# Altium schematic sheet MiniF4xx_v2 - 副本.SchDoc
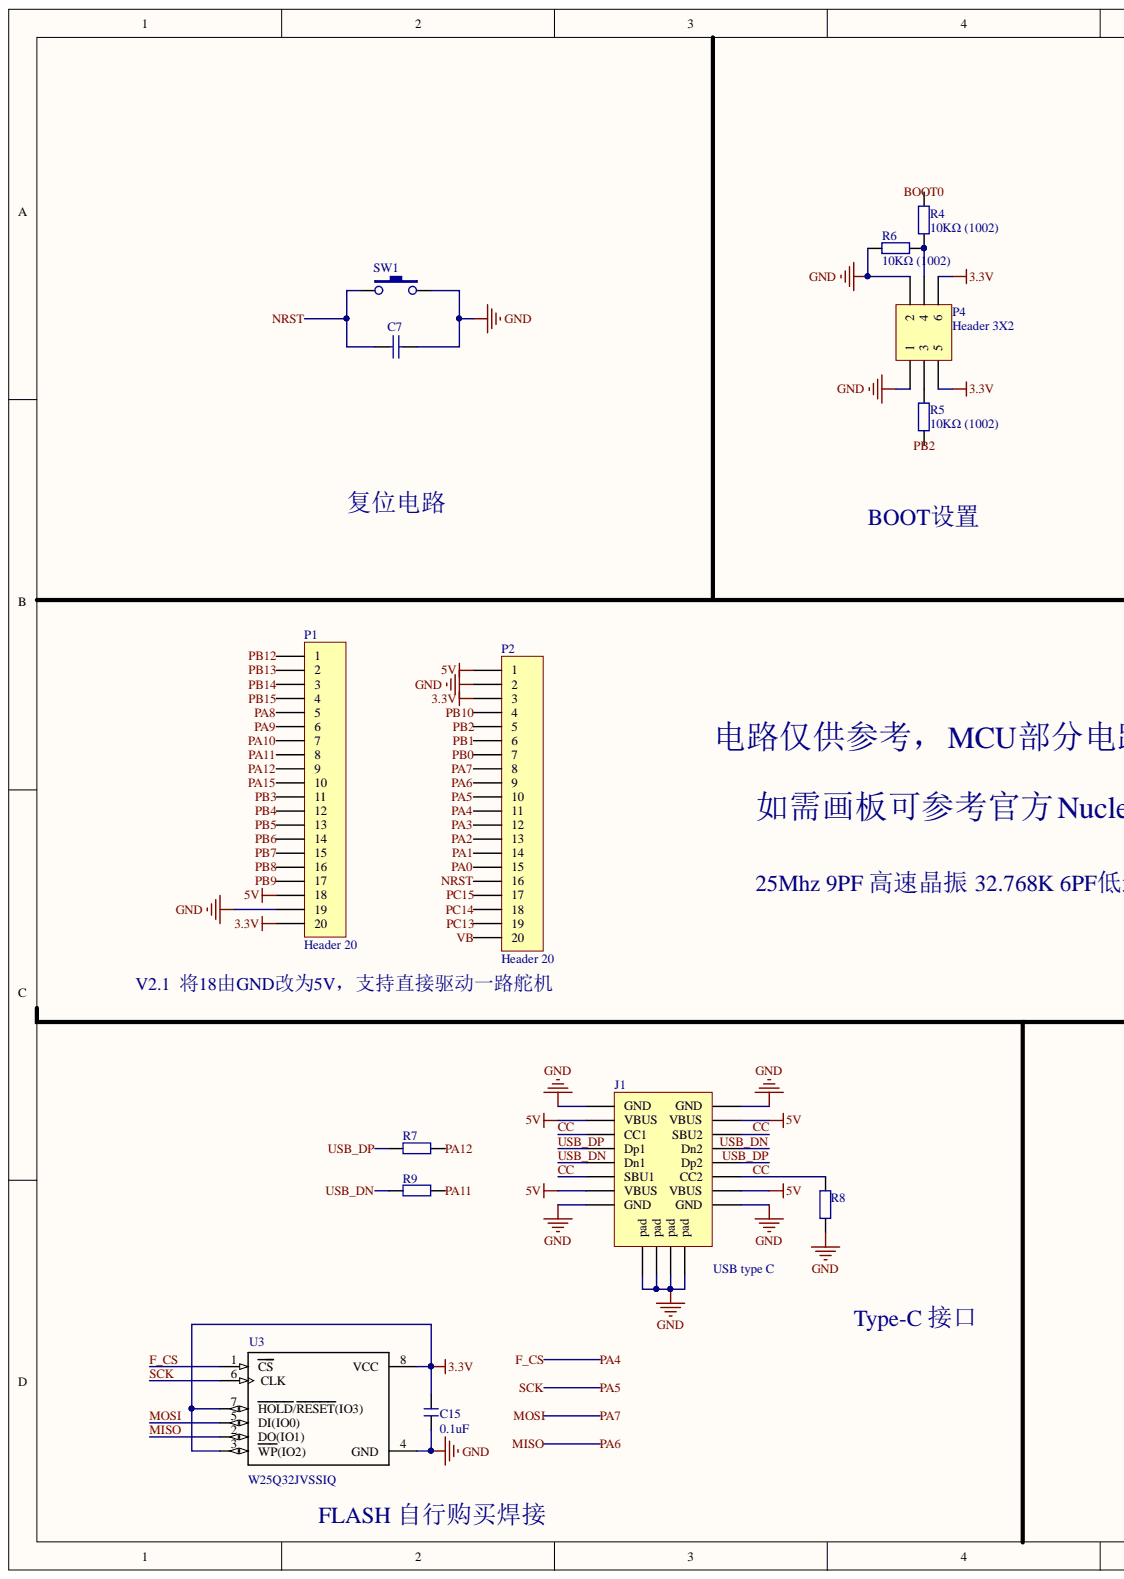
[diagram p0: MiniF4xx_v2 - 副本.SchDoc, left]
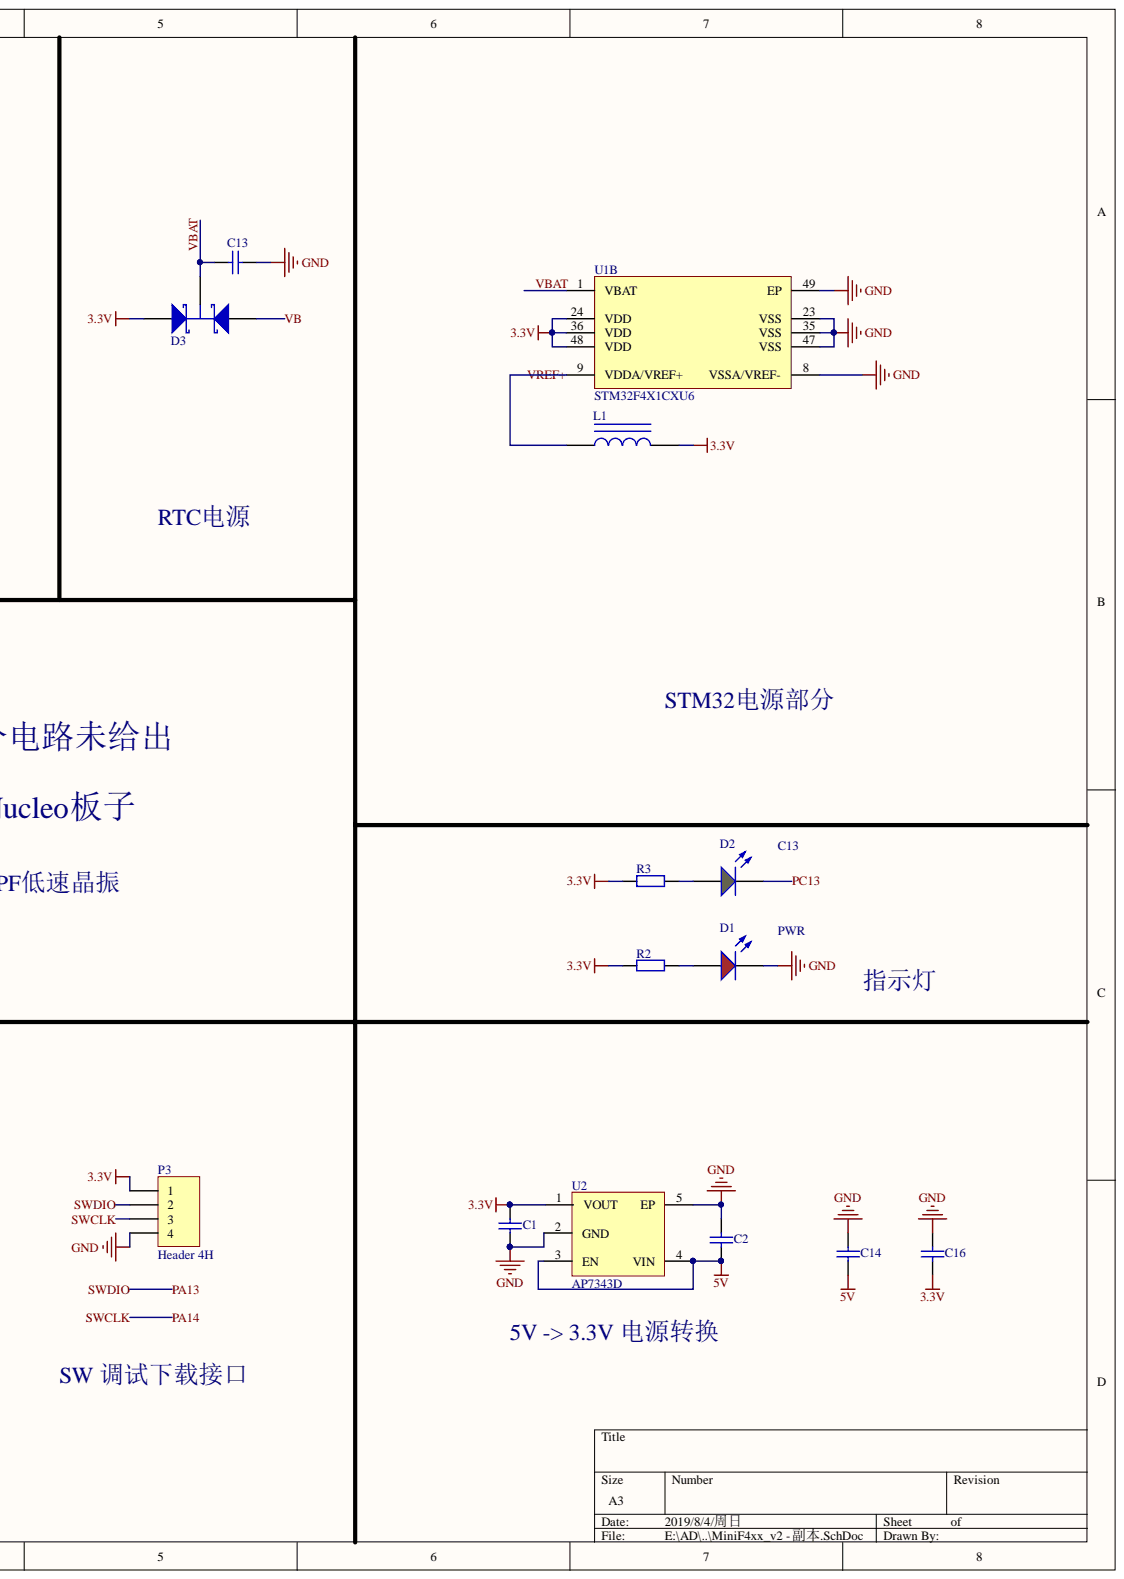
[diagram p1: MiniF4xx_v2 - 副本.SchDoc, right]

## Parts
C1  1.0uF  [0402_C]  (value not drawn)
C2  1.0uF  [0402_C]  (value not drawn)
C7  100nF  [0402_C]  (value not drawn)
C13  100nF  [0402_C]  (value not drawn)
C14  100nF  [0402_C]  (value not drawn)
C15  0.1uF  [C 0402]
C16  100nF  [0402_C]  (value not drawn)
D1  红灯 0603  [0603_LED_S1]  (value not drawn)
D2  蓝灯 0603  [0603_LED_S1]  (value not drawn)
D3  BAT54C  [SOT23_N]  (value not drawn)
J1  USB type C  [TYPE-C-USB-17]
L1  10uH ±10%  [0603_L]  (value not drawn)
P1  Header 20  [HDR1X20]
P2  Header 20  [HDR1X20]
P3  Header 4H  [HDR1X4H]
P4  Header 3X2  [SMD-2*3/1.27]
R2  1K  [0402_R]  (value not drawn)
R3  1K  [0402_R]  (value not drawn)
R4  10KΩ (1002)  [0402_R]
R5  10KΩ (1002)  [0402_R]
R6  10KΩ (1002)  [0402_R]
R7  10Ω  [0402_R]  (value not drawn)
R8  5.1KΩ  [0402_R]  (value not drawn)
R9  10Ω  [0402_R]  (value not drawn)
SW1  3*4 按键  [KEY-3.0*4.0_]  (value not drawn)
U1  STM32F4X1CXU6  [STM-UFQFPN48_N]  (part B)
U2  AP7343D  [X2-DFN1010-4]
U3  W25Q32JVSSIQ  [*SOIC127P790X216-8N]

## Nets
3.3V: C1.2 C15.1 C16.1 D3.1 L1.2 P1.20 P2.3 P3.1 P4.5 P4.6 R2.1 R3.1 U1.24(VDD) U1.36(VDD) U1.48(VDD) U2.1(VOUT) U3.3(WP(IO2)) U3.7(HOLD/RESET(IO3)) U3.8(VCC)
5V: C2.1 C14.1 J1.A4(VBUS) J1.A9(VBUS) J1.B4(VBUS) J1.B9(VBUS) P1.18 P2.1 U2.3(EN) U2.4(VIN)
GND: C1.1 C2.2 C7.2 C13.1 C14.2 C15.2 C16.2 D1.K J1.0(pad) J1.A1(GND) J1.A12(GND) J1.B1(GND) J1.B12(GND) P1.19 P2.2 P3.4 P4.1 P4.2 R6.2 R8.1 SW1.2 U1.8(VSSA/VREF-) U1.23(VSS) U1.35(VSS) U1.47(VSS) U1.49(EP) U2.2(GND) U2.5(EP) U3.4(GND)
BOOT0: R4.2
CC: J1.A5(CC1) J1.A8(SBU1) J1.B5(CC2) J1.B8(SBU2) R8.2
F_CS: P2.11 U3.1(CS)
MISO: P2.9 U3.2(DO(IO1))
MOSI: P2.8 U3.5(DI(IO0))
NRST: C7.1 P2.16 SW1.1
PA0: P2.15
PA1: P2.14
PA2: P2.13
PA3: P2.12
PA5: P2.10 U3.6(CLK)
PA8: P1.5
PA9: P1.6
PA10: P1.7
PA11: P1.8 R9.1
PA12: P1.9 R7.1
PA13: P3.2
PA14: P3.3
PA15: P1.10
PB0: P2.7
PB1: P2.6
PB2: P2.5 R5.1
PB3: P1.11
PB4: P1.12
PB5: P1.13
PB6: P1.14
PB7: P1.15
PB8: P1.16
PB9: P1.17
PB10: P2.4
PB12: P1.1
PB13: P1.2
PB14: P1.3
PB15: P1.4
PC13: D2.K P2.19
PC14: P2.18
PC15: P2.17
USB_DN: J1.A7(Dn1) J1.B7(Dn2) R9.2
USB_DP: J1.A6(Dp1) J1.B6(Dp2) R7.2
VB: D3.2 P2.20
VBAT: C13.2 D3.3 U1.1(VBAT)
VREF+: L1.1 U1.9(VDDA/VREF+)
NetD1_A: D1.A R2.2
NetD2_A: D2.A R3.2
NetP4_3: P4.3 R5.2
NetP4_4: P4.4 R4.1 R6.1

## Library data of the parts
C1 C2 = 0402 1.0uF (105) 10% 25V: 贴片电容; Component Kind: Standard; ComponentLink1Description: 供应商链接; ComponentLink1URL: http://www.szlcsc.com/product/details_53938.html; Library Name: Cap.SchLib; Package: 0402; Supplier: LC; SuppliersPartNumber: C52923
C7 C13 C14 C16 = 0402 100nF (104) 10% 16V: 贴片电容; Component Kind: Standard; ComponentLink1Description: 供应商链接; ComponentLink1URL: http://www.szlcsc.com/product/details_1877.html; Library Name: Cap.SchLib; Package: 0402; Supplier: LC; SuppliersPartNumber: C1525
C15 = C: 无极性贴片电容; 版权归属: 源创客; BOM名称: 无极性贴片电容; Component Kind: Standard; Library Name: PMW3901 V1.1.SCHLIB; 学习资源: www.ooocke.com
D1 = 0603 红灯: 发光二极管; Component Kind: Standard; ComponentLink1Description: 供应商链接; ComponentLink1URL: http://www.szlcsc.com/product/details_2659.html; Library Name: LED.SchLib; Package: 0603; Supplier: LC; SuppliersPartNumber: C2286
D2 = 0603 绿灯: 发光二极管; Component Kind: Standard; ComponentLink1Description: 供应商链接; ComponentLink1URL: http://www.szlcsc.com/product/details_73152.html; Library Name: LED.SchLib; Package: 0603; Supplier: LC; SuppliersPartNumber: C72043
D3 = BAT54C: Schottky Barrier Double Diode; Component Kind: Standard; ComponentLink1Description: Manufacturer Link; ComponentLink1URL: http://www.semiconductors.philips.com/; ComponentLink2Description: Datasheet; ComponentLink2URL: http://www.semiconductors.philips.com/acrobat/datasheets/BAT54_SERIES_4.pdf; DatasheetVersion: 4-Mar-2002; LatestRevisionDate: 12-Dec-2006; LatestRevisionNote: IPC-7351 Footprint Added.; Library Name: MiniF401CB.IntLib; PackageDescription: 3-Pin SOT-23 Package 0.95 mm Pitch, 2.3 mm Lead Span; PackageReference: SOT23; PackageVersion: May-1999; Published: 17-Sep-2003; Signal Integrity: BAT54C; Simulation: BAT54C; Publisher: Altium Limited
J1 = USB_C: Component Kind: Standard; Library Name: LicheeDan.SCHLIB
L1 = 0603 4.7uH 10%: 贴片电感; Component Kind: Standard; ComponentLink1Description: 供应商链接; ComponentLink1URL: http://www.szlcsc.com/product/details_1386.html; Library Name: IUD.SchLib; Package: 0603; Supplier: LC; SuppliersPartNumber: C1034
P1 P2 = Header 20: Header, 20-Pin; Component Kind: Standard; LatestRevisionDate: 17-Jul-2002; LatestRevisionNote: Re-released for DXP Platform.; Library Name: Miscellaneous Connectors.IntLib; Signal Integrity: Connector; Publisher: Altium Limited
P3 = Header 4H: Header, 4-Pin, Right Angle; Component Kind: Standard; LatestRevisionDate: 17-Jul-2002; LatestRevisionNote: Re-released for DXP Platform.; Library Name: Miscellaneous Connectors.IntLib; Signal Integrity: Connector; Publisher: Altium Limited
P4 = Header 3X2: Header, 3-Pin, Dual row; Component Kind: Standard; LatestRevisionDate: 17-Jul-2002; LatestRevisionNote: Re-released for DXP Platform.; Library Name: Miscellaneous Connectors.IntLib; Signal Integrity: Connector; Publisher: Altium Limited
R2 R3 = 0402 510KΩ (5103) 1%: 贴片电阻; Component Kind: Standard; ComponentLink1Description: 供应商链接; ComponentLink1URL: http://www.szlcsc.com/product/details_12170.html; Library Name: RES.SchLib; Package: 0402; Supplier: LC; SuppliersPartNumber: C11616
R4-R7 R9 = 0402 10KΩ (1002) 1%: 贴片电阻; Component Kind: Standard; ComponentLink1Description: 供应商链接; ComponentLink1URL: http://www.szlcsc.com/product/details_26487.html; Library Name: RES.SchLib; Package: 0402; Supplier: LC; SuppliersPartNumber: C25744
R8 = 0402 5.1KΩ (5101) 1%: 贴片电阻; Component Kind: Standard; ComponentLink1Description: 供应商链接; ComponentLink1URL: http://www.szlcsc.com/product/details_26648.html; Library Name: RES.SchLib; Package: 0402; Supplier: LC; SuppliersPartNumber: C25905
SW1 = SW-PB_V: SWITCH; Component Kind: Standard; Library Name: Integrated_Library1.IntLib
U1 = STM32F401CBU6: ARM Cortex-M4 32-bit MCU+FPU, 105 DMIPS, 128 kB Flash, 64 kB Internal RAM, 36 I/Os, 48-pin UFQFPN, -40 to 85 degC, Tray; Manufacturer: STMicroelectronics; FLASH Size (Prog) (kB): 128; I/Os (High Current): 36; Internal RAM Size (kB): 64; Operating Frequency(F) (Processor speed) (MHz): 84; Supply Voltage(Vcc) max (V): 3.6; Supply Voltage(Vcc) min (V): 1.8; Component Kind: Standard; ComponentLink1Description: Manufacturer URL; ComponentLink1URL: http://www.st.com/web/en/home.html; ComponentLink2Description: Datasheet; ComponentLink2URL: http://www.st.com/st-web-ui/static/active/en/resource/technical/document/data_brief/DM00071938.pdf; ComponentLink3Description: Package Specification; ComponentLink3URL: http://www.st.com/st-web-ui/static/active/en/resource/technical/document/data_brief/DM00071938.pdf; Core: ARM Cortex-M4; DatasheetVersion: Rev. 1; Library Name: STMicroelectronics STM32 F4.IntLib; Mounting Technology: Surface Mount; PackageDescription: 48-Pin Ultra Thin Fine Pitch Quad Flat No-Lead, 7 x 7 x 0.6 mm Body, 0.5 mm Pitch, Exposed Pad; PackageReference: UFQFPN48; PackageVersion: Rev. 1, 04/2013; Packing: Tray; PartNumber: STM32F401CBU6; Supplier 1: Newark; Supplier 2: Mouser; Supplier Part Number 1: 19X6215; Supplier Part Number 2: 511-STM32F401CBU6
U2 = AD7343-DFN: Component Kind: Standard; Library Name: zhuyix.IntLib
U3 = W25Q32JVSSIQ: NOR闂瓨涓茶SPI 3V 32Mbit 32M x 1浣?寮曡剼SOIC; MF: Winbond; Availability: Unavailable; Component Kind: Standard; Library Name: W25Q32JVSSIQ.IntLib; MP: W25Q32JVSSIQ; Package: SOIC-8 Winbond; Price: None; SnapEDA_Link: https://www.snapeda.com/parts/W25Q32JVSSIQ/Winbond%20Electronics/view-part/?ref=snap
